# Altium schematic sheet CR99001.SchDoc(CR99001)
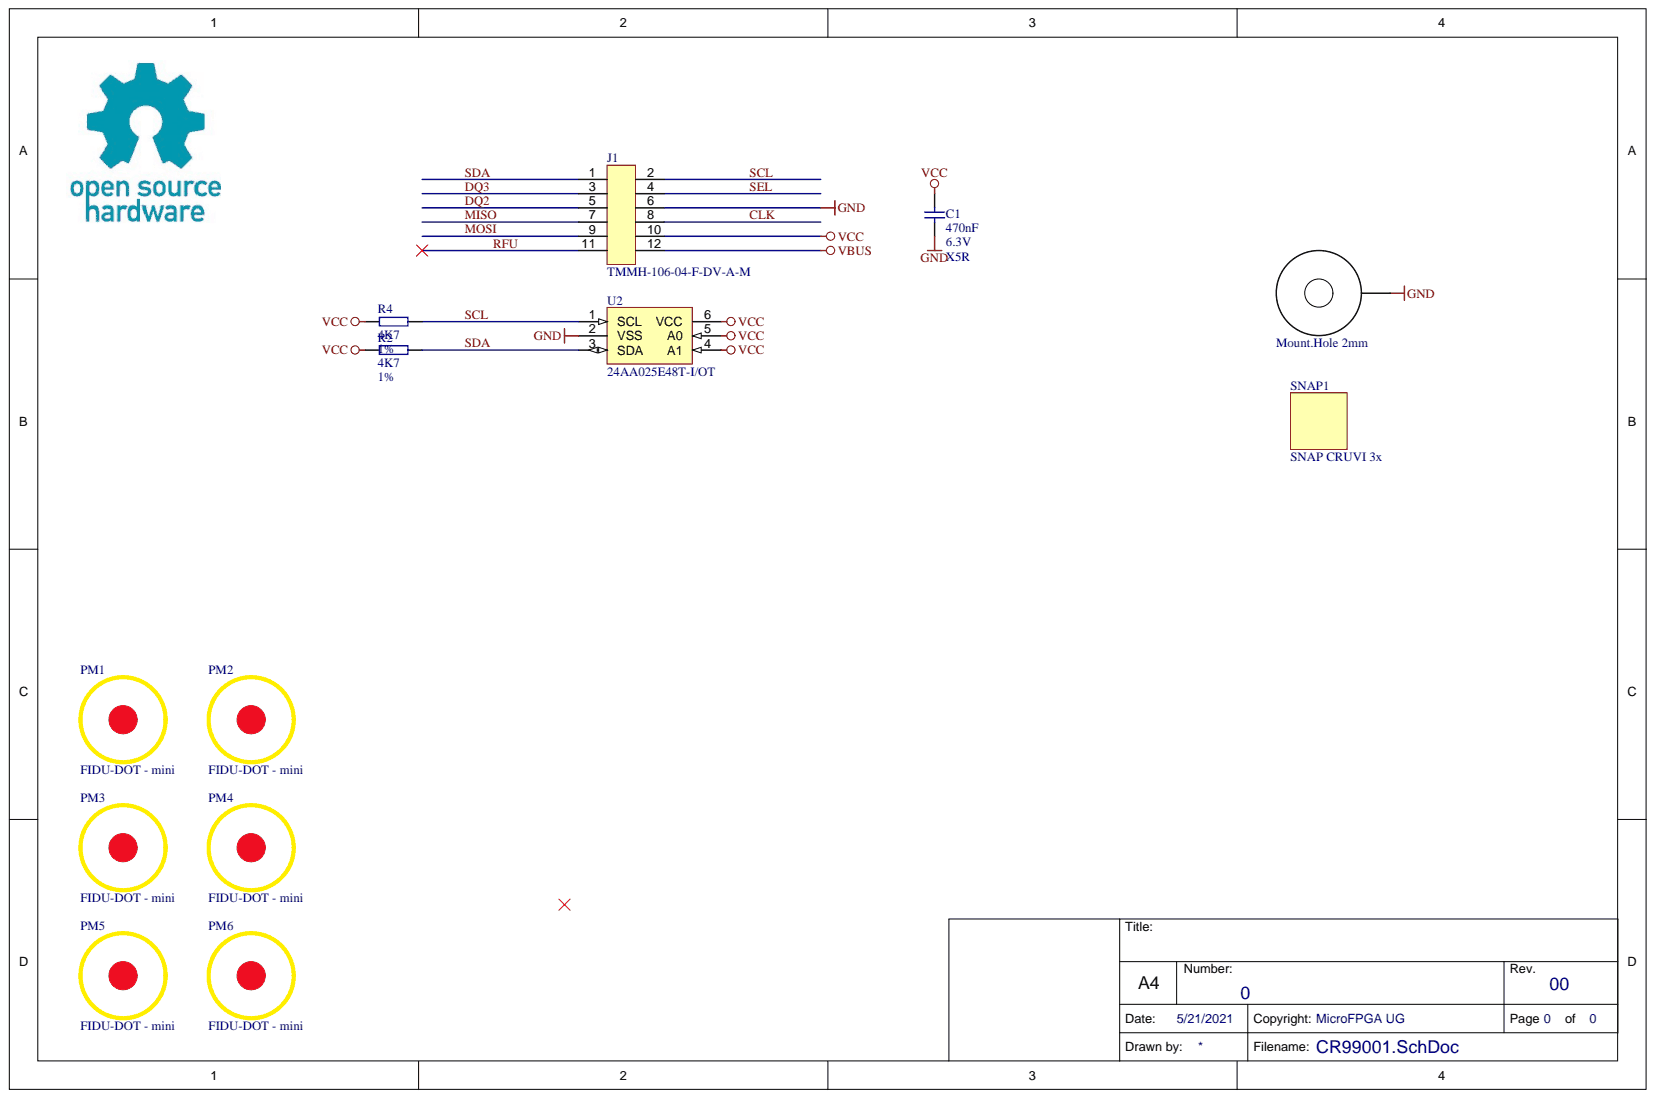
[diagram p0: CR99001.SchDoc]

## Parts
C1  470nF  Technology: X5R  Voltage: 6.3V  [CAPC1005X55N]
H1  Mount.Hole 2mm  [MHole2_2mm]  (no BOM)
J1  TMMH-106-04-F-DV-A-M  [TMMH-106-04-T-DV-A]
PM1  FIDU-DOT - mini  [FIDU-DOT - mini]
PM2  FIDU-DOT - mini  [FIDU-DOT - mini]
PM3  FIDU-DOT - mini  [FIDU-DOT - mini]
PM4  FIDU-DOT - mini  [FIDU-DOT - mini]
PM5  FIDU-DOT - mini  [FIDU-DOT - mini]
PM6  FIDU-DOT - mini  [FIDU-DOT - mini]
R2  4K7  Tolerance: 1%  [RESC1005X40N]
R4  4K7  [RESC1005X40N]
SNAP1  SNAP CRUVI 3x  [CRUVI_3x_Snap]  (no BOM)
U2  24AA025E48T-I/OT  [SOT95P280X145-6N]

## Nets
GND: C1.2 H1.1 J1.6 U2.2(VSS)
VBUS: J1.12
VCC: C1.1 J1.10 R2.1 R4.1 U2.4(A1) U2.5(A0) U2.6(VCC)
CLK: J1.8
DQ2: J1.5
DQ3: J1.3
MISO: J1.7
MOSI: J1.9
RFU: J1.11
SCL: J1.2 R4.2 U2.1(SCL)
SDA: J1.1 R2.2 U2.3(SDA)
SEL: J1.4

## Library data of the parts
C1 = 23659: KON 470nF 6,3V X5R SMD BF 1005 (0402); Database Table Name: C_1005_0402; Mixed: SMT; Preferred: Yes; Temperature-Range: -55/+85; Value: 470n; AN: 23659; Case: 1005 (0402); Component Kind: Standard; Library Name: TE.DbLib
H1 = 27273: Database Table Name: PCB; Mixed: THT; AN: 27273; Description: Mount.Hole 2mm; Library Name: TE.DbLib
J1 = 28976: Database Table Name: CON_HEADER; Mixed: SMT; AN: 28976; Component Kind: Standard; Description: TMMH-106-04-F-DV-A-M; H-ART: TMMH-106-04-F-DV-A-M; Library Name: TE.DbLib; HelpURL: b:\AD\adlib\datasheet\Samtec_TMMH\
PM1-PM6 = 27037: FIDU-DOT - MINI 0.5mm; Database Table Name: PCB; Mixed: FIDU; AN: 27037; Component Kind: Standard; Library Name: TE.DbLib
R2 R4 = 22585: WID SMD 4K7 1% 0,063W BF 1005 (0402); Database Table Name: R_1005_0402; H-ART: RC0402FR-134K7L; Mixed: SMT; Preferred: Yes; Value: 4.7K; AN: 22585; Case: 1005 (0402); Component Kind: Standard; Library Name: TE.DbLib; Technology: TK100
  R4: Tolerance: 1%
SNAP1 = 29977: SNAP for CRUVI 3x; Database Table Name: BLOCKS; AN: 29977; Library Name: TE.DbLib
U2 = 26249: Database Table Name: EEPROM; Mixed: SMT; Temperature-Range: -40/+85; AN: 26249; Case: SOT23-6; Component Kind: Standard; Description: 24AA025E48T-I/OT; H-ART: 24AA025E48T-I/OT; Library Name: TE.DbLib; HelpURL: B:\AD\adlib\datasheet\24AA02xExx
